# Altium schematic sheet Relay V1.2.SchDoc
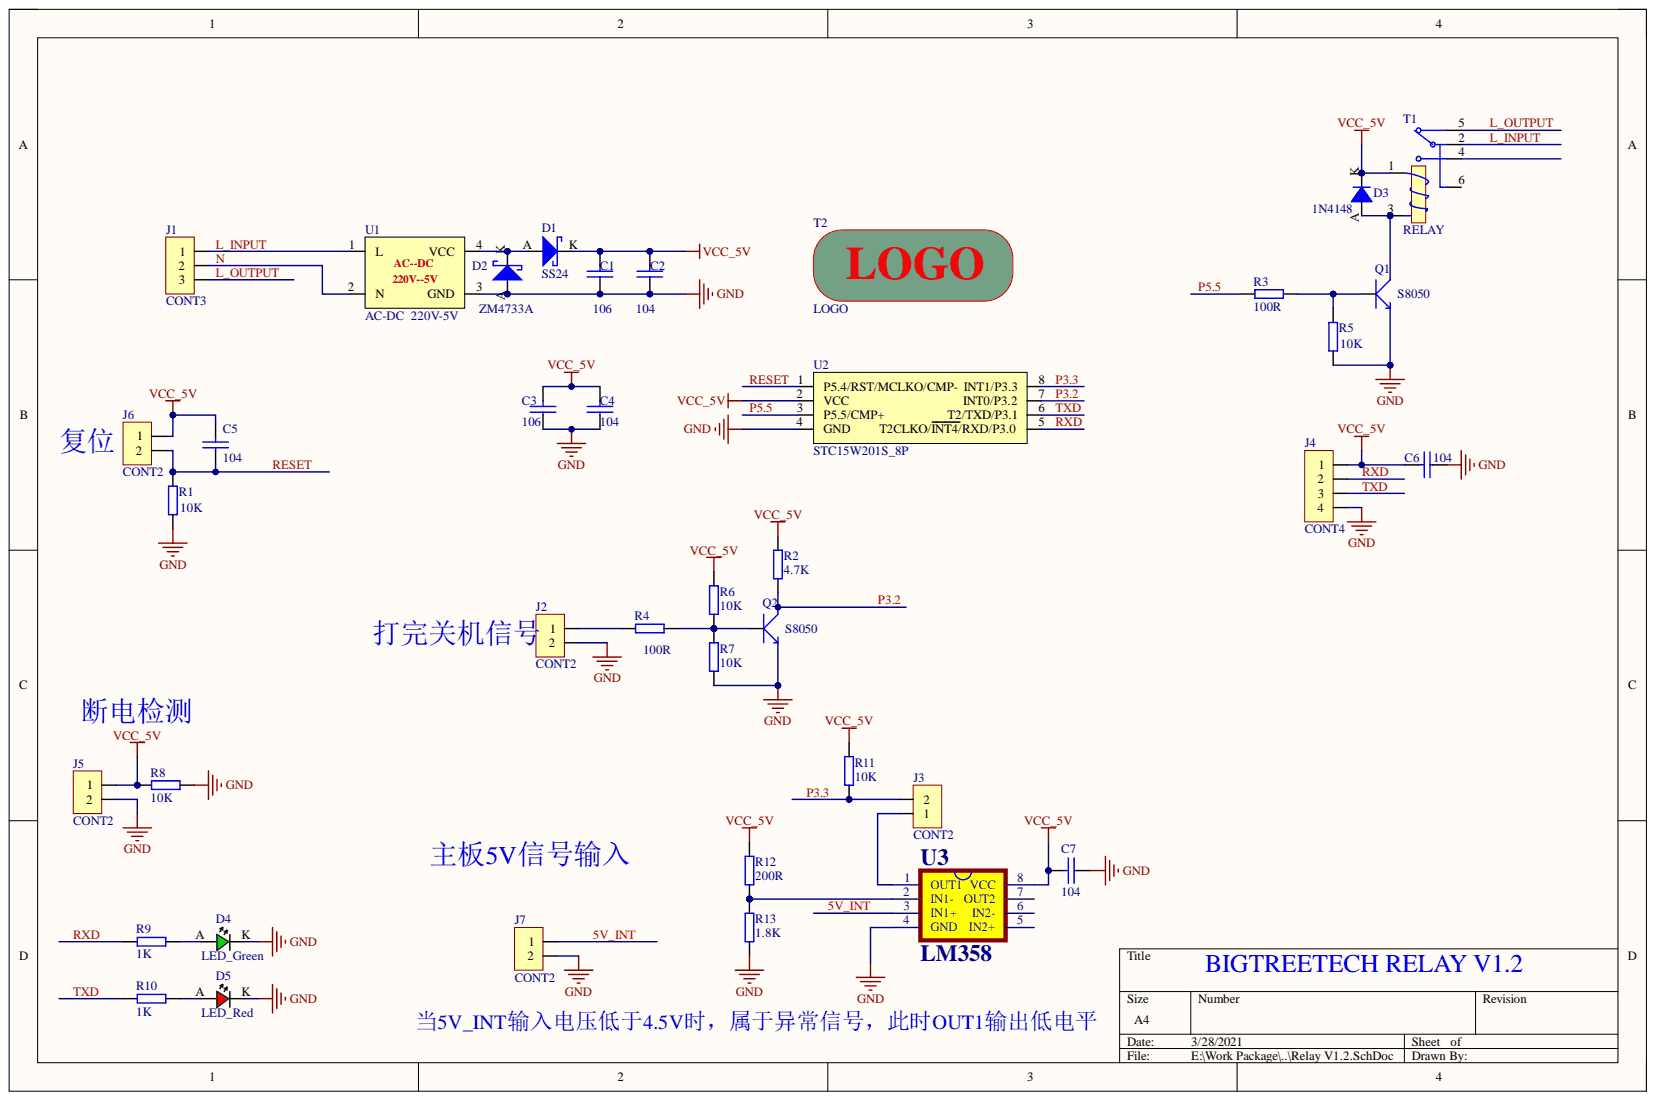
[diagram p0: Relay V1.2.SchDoc]

## Parts
C1  106  [C_0805]
C2  104  [C_0603]
C3  106  [C_0805]
C4  104  [C_0603]
C5  104  [C_0603]
C6  104  [C_0603]
C7  104  [C_0603]
D1  SS24  [D_SMA_DO_214AC]
D2  ZM4733A  [D_LL-41]
D3  1N4148  [D_1206]
D4  LED_Green  [LED_0603]
D5  LED_Red  [LED_0603]
J1  CONT3  [HB_9500_3P]
J2  CONT2  [2.54-2P-2]
J3  CONT2  [SIP2_2MM54]
J4  CONT4  [SIP4_2MM54]
J5  CONT2  [2.54-2P-2]
J6  CONT2  [SIP2_2MM54]
J7  CONT2  [2.54-2P-2]
Q1  S8050  [SOT_23_BEC]
Q2  S8050  [SOT_23_BEC]
R1  10K  [R_0603]
R2  4.7K  [R_0603]
R3  100R  [R_0603]
R4  100R  [R_0603]
R5  10K  [R_0603]
R6  10K  [R_0603]
R7  10K  [R_0603]
R8  10K  [R_0603]
R9  1K  [R_0603]
R10  1K  [R_0603]
R11  10K  [R_0603]
R12  200R  [R_0603]
R13  1.8K  [R_0603]
T1  RELAY  [RELAY_05VDC]
T2  LOGO  [国际静电标志(24.4*32.5mm)]
U1  AC-DC  220V-5V  [AC-DC 220V-5V]
U2  STC15W201S_8P  [SOP_8]
U3  LM358  [SOP_8]

## Nets
GND: C1.2 C2.2 C3.2 C4.2 C6.2 C7.2 D2.A D4.K D5.K J2.2 J4.4 J5.2 J7.2 Q1.E Q2.E R1.1 R5.1 R7.2 R8.1 R13.2 U1.3(GND) U2.4(GND) U3.4(GND)
VCC_5V: C1.1 C2.1 C3.1 C4.1 C5.1 C6.1 C7.1 D1.K D3.K J4.1 J5.1 J6.1 R2.1 R6.1 R8.2 R11.1 R12.1 T1.1 U2.2(VCC) U3.8(VCC)
5V_INT: J7.1 U3.3(IN1+)
L_INPUT: J1.1 T1.2 U1.1(L)
L_OUTPUT: J1.3 T1.5
N: J1.2 U1.2(N)
P3.2: Q2.C R2.2 U2.7(INT0/P3.2)
P3.3: J3.2 R11.2 U2.8(INT1/P3.3)
P5.5: R3.2 U2.3(P5.5/CMP+)
RESET: C5.2 J6.2 R1.2 U2.1(P5.4/RST/MCLKO/CMP-)
RXD: J4.2 R9.2 U2.5(T2CLKO/INT4/RXD/P3.0)
TXD: J4.3 R10.2 U2.6(T2/TXD/P3.1)
NetD1_A: D1.A D2.K U1.4(VCC)
NetD3_A: D3.A Q1.C T1.3
NetD4_A: D4.A R9.1
NetD5_A: D5.A R10.1
NetJ2_1: J2.1 R4.2
NetJ3_1: J3.1 U3.1(OUT1)
NetQ1_B: Q1.B R3.1 R5.2
NetQ2_B: Q2.B R4.1 R6.2 R7.1
NetR12_2: R12.2 R13.1 U3.2(IN1-)
BIGTREETECH RELAY V1.2:
主板5V信号输入:
复位:
当5V_INT输入电压低于4.5V时，属于异常信号，此时OUT1输出低电平:
打完关机信号:
断电检测:

Not connected: T1 4 6; U3 5(IN2+) 6(IN2-) 7(OUT2)

## Library data of the parts
C1-C7 = CAP: 电容; Component Kind: Standard; Library Name: 常规.SchLib
D1 D2 = DIODE_SBD: 肖特基二极管; Component Kind: Standard; Library Name: 常规.SchLib
D3 = DIODE: Component Kind: Standard; Library Name: 常规.SchLib
Q1 Q2 = NPN: Component Kind: Standard; Description: NPN; Library Name: 常规.SchLib
R1-R13 = RES: 电阻; Component Kind: Standard; Library Name: 常规.SchLib
T1 = RELAY: 继电器; Component Kind: Standard; Library Name: 常规.SchLib
U2 = STC15W201S: Component Kind: Standard; Library Name: 芯片.SchLib
D4 = LED_Green: Component Kind: Standard; Library Name: 常规.SchLib
D5 = LED_Red: Component Kind: Standard; Library Name: 常规.SchLib
J1 = CONT3: Component Kind: Standard; Library Name: 常规.SchLib
J2 J3 J5-J7 = CONT2: Component Kind: Standard; Library Name: 常规.SchLib
J4 = CONT4: Component Kind: Standard; Library Name: 常规.SchLib
T2 = LOGO: Component Kind: Standard; Library Name: 特殊.SchLib
U1 = AC-DC  220V-5V: Component Kind: Standard; Library Name: 特殊.SchLib
U3 = LM358: Component Kind: Standard; Library Name: 芯片.SchLib
